# Altium schematic sheet FTDI ISO.SchDoc("FTDI ISO")
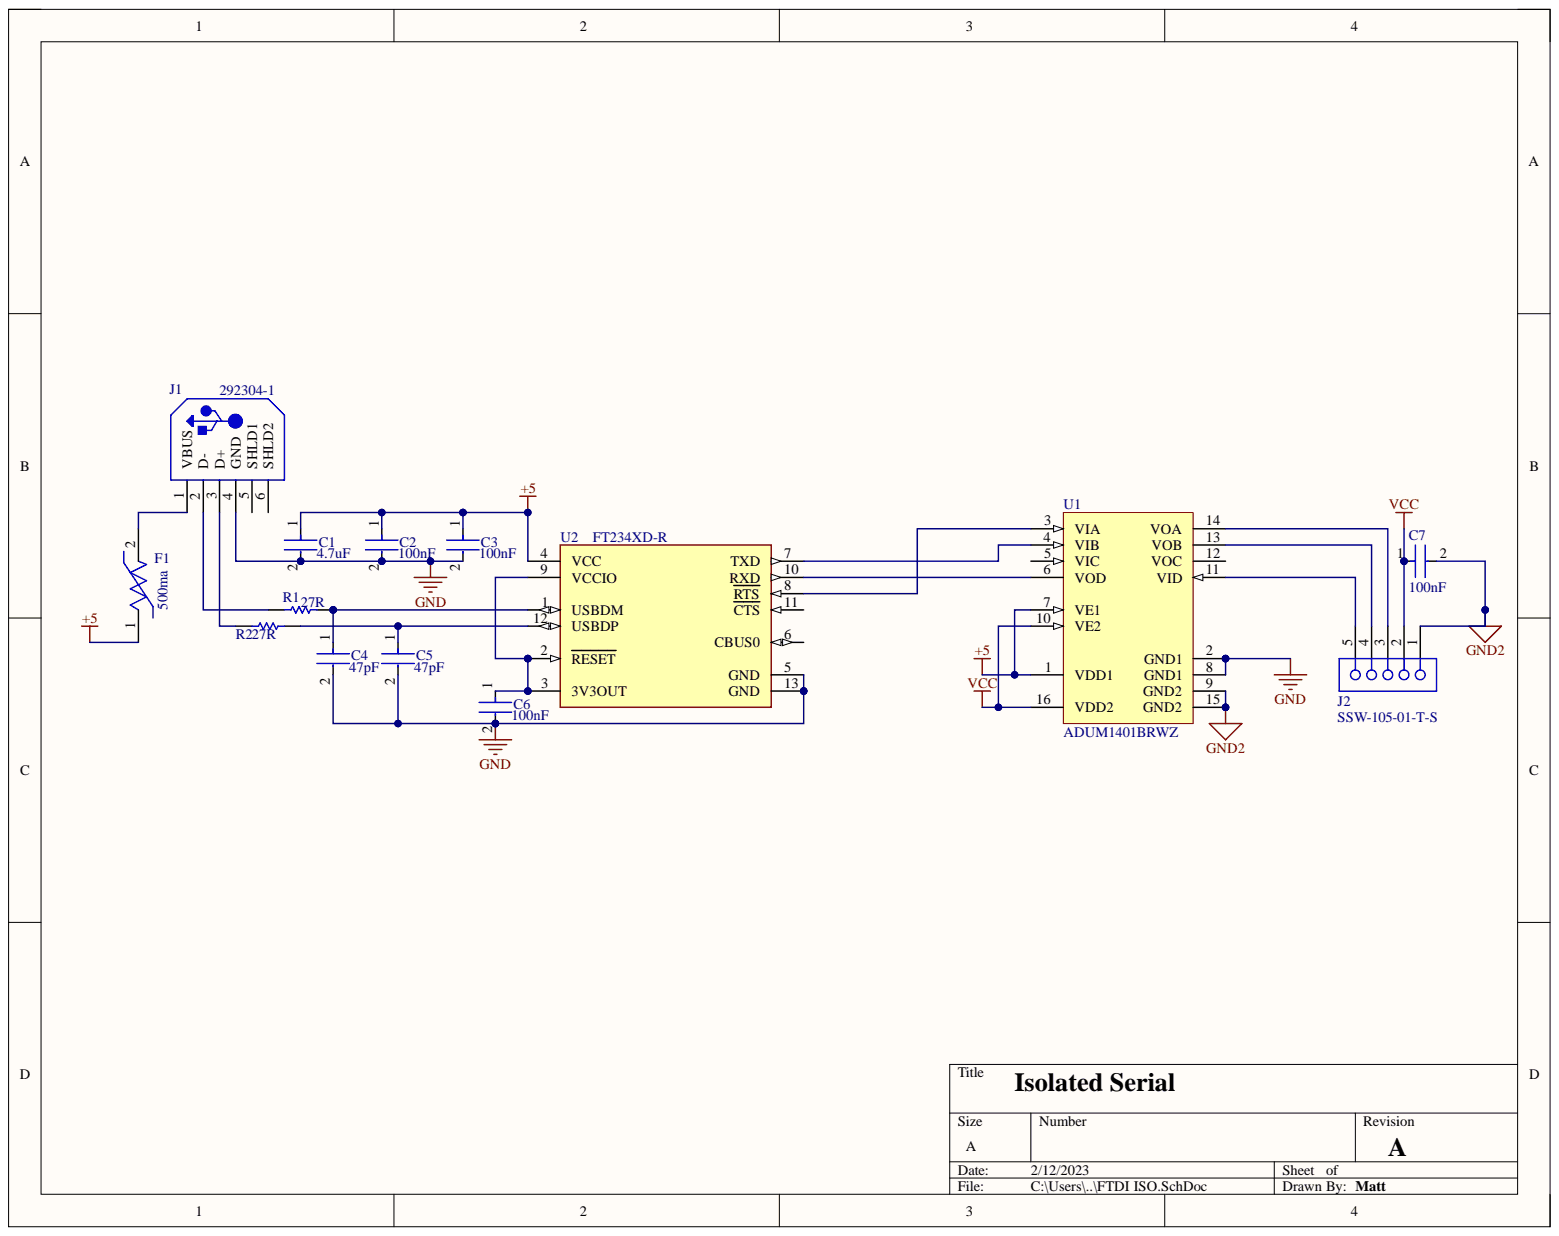
[diagram p0: FTDI ISO.SchDoc]

## Parts
C1  Capacitance: 4.7uF  [FP-CL21-IPC_B]  (hidden comment: CL21A475KAQNNNG)
C2  Capacitance: 100nF  [FP-CL10-IPC_A]  (hidden comment: CL10B104KA8WPNC)
C3  Capacitance: 100nF  [FP-CL10-IPC_A]  (hidden comment: CL10B104KA8WPNC)
C4  Capacitance: 47pF  [FP-0603-L_1_6_0_15-W_0_81-MFG]  (hidden comment: 06035A470JAT2A)
C5  Capacitance: 47pF  [FP-0603-L_1_6_0_15-W_0_81-MFG]  (hidden comment: 06035A470JAT2A)
C6  Capacitance: 100nF  [FP-CL10-IPC_A]  (hidden comment: CL10B104KA8WPNC)
C7  Capacitance: 100nF  [FP-CL10-IPC_A]  (hidden comment: CL10B104KA8WPNC)
F1  500ma  [FP-1206L050YR-MFG]
J1  292304-1  [TECO-292304-1-4_V]
J2  SSW-105-01-T-S  [SMTC-SSW-105-01-X-S]
R1  Parameter 1: 27R  [RESC1005X40X25NL05T10]  (hidden comment: RC0402JR-0727RL)
R2  Parameter 1: 27R  [RESC1005X40X25NL05T10]  (hidden comment: RC0402JR-0727RL)
U1  ADUM1401BRWZ  [ADI-RW-16_M]
U2  FT234XD-R  [FP-DFN-12-IPC_B]

## Nets
+5: C1.1 C2.1 C3.1 F1.1 U1.1(VDD1) U1.7(VE1) U2.4(VCC)
GND: C1.2 C2.2 C3.2 C4.2 C5.2 C6.2 J1.4(GND) U1.2(GND1) U1.8(GND1) U2.5(GND) U2.13(GND)
GND2: C7.2 J2.1 U1.9(GND2) U1.15(GND2)
VCC: C7.1 J2.2 U1.10(VE2) U1.16(VDD2)
NetC4_1: C4.1 R1.2 U2.1(USBDM)
NetC5_1: C5.1 R2.2 U2.12(USBDP)
NetC6_1: C6.1 U2.2(RESET) U2.3(3V3OUT) U2.9(VCCIO)
NetF1_2: F1.2 J1.1(VBUS)
NetJ1_2: J1.2(D-) R1.1
NetJ1_3: J1.3(D+) R2.1
NetJ2_3: J2.3 U1.14(VOA)
NetJ2_4: J2.4 U1.13(VOB)
NetJ2_5: J2.5 U1.11(VID)
NetU1_3: U1.3(VIA) U2.8(RTS)
NetU1_4: U1.4(VIB) U2.7(TXD)
NetU1_6: U1.6(VOD) U2.10(RXD)

Not connected: J1 5(SHLD1) 6(SHLD2); U1 5(VIC) 12(VOC); U2 6(CBUS0) 11(CTS)

## Library data of the parts
C1 = CMP-13271-004010-1: CL21 Series 0805 4.7uF 25V ±10% Tolerance X5R Multilayer Ceramic Chip Capacitor; Case Code (Imperial): 0805; Case Code (Metric): 2012; Case/Package: 0805; Component Kind: Standard; Depth: 1.25mm; Dielectric: X5R; Height: 1.25mm; Lead Free: Lead Free; Length: 2mm; Library Name: Altium Content Vault; Material: Ceramic; Max Operating Temperature: 85°C; Min Operating Temperature: -55°C; Mount: Surface Mount; Package Quantity: 3000; Packaging: Tape and Reel; Radiation Hardening: No; Thickness: 0.055inch; Tolerance: 10%; Voltage: 25V; Voltage Rating: 25V; Width: 0.049inch
C2 C3 C6 C7 = CMP-13271-003556-1: Cap Ceramic 100nF 25V X7R ±10% Pad SMD 0603 +125°C T/R; Case Code (Imperial): 0603; Case Code (Metric): 1608; Case/Package: 0603; Component Kind: Standard; Features: Soft Termination; Length: 0.063inch; Library Name: Altium Content Vault; Max Operating Temperature: 125°C; Min Operating Temperature: -55°C; Mount: Surface Mount; Packaging: Tape and Reel; Ratings: AEC-Q200; Thickness: 0.035inch; Tolerance: 10%; Voltage Rating: 25V; Width: 0.031inch
C4 C5 = CMP-2006-04316-2: General Purpose Ceramic Capacitor, 0603, 47pF, 5%, NP0, 30ppm/°C, 50V; Dielectric: C0G; Case Code (Imperial): 0603; Case Code (Metric): 1608; Case/Package: 0603; Component Kind: Standard; Contact Plating: Tin; Depth: 0.81mm; Height: 0.9mm; Lead Free: Lead Free; Length: 1.6mm; Library Name: Altium Content Vault; Max Operating Temperature: 125°C; Min Operating Temperature: -55°C; Mount: Surface Mount; Number of Pins: 2; Package Quantity: 1; Packaging: Tape and Reel; Radiation Hardening: No; REACH SVHC: No SVHC; RoHS Compliant: Yes; Temperature Coefficient: 30ppm/°C; Termination: SMD/SMT; Thickness: 0.035inch; Tolerance: 5%; Voltage: 50V; Voltage Rating: 50V; Voltage Rating (DC): 50V; Width: 0.032inch
F1 = CMP-08606-000073-1: PTC RESET FUSE 6V 500MA 1206; Approvals: cULus; Current Rating: 100A; Max Power Dissipation: 600mW; Resistance: 700mR; Time to Trip: 0.1s; Trip Current: 1A; Case Code (Imperial): 1206; Case Code (Metric): 3216; Case/Package: 1206; Component Kind: Standard; Height: 0.75mm; Hold Current: 500mA; Lead Free: Lead Free; Length: 3.4mm; Library Name: Altium Content Vault; Max Current Rating: 100A; Max Operating Temperature: 85°C; Max Voltage Rating (DC): 6V; Min Operating Temperature: -40°C; Operating Supply Voltage: 6V; Package Quantity: 4000; Packaging: Tape and Reel; Power Dissipation: 600mW; REACH SVHC: No SVHC; RoHS Compliant: Yes; Series: 1206L; Terminal Material: Copper; Termination: SMD/SMT; Voltage Rating: 6V; Voltage Rating (DC): 6V; Width: 1.8mm; Working Voltage: 6V
J1 = CMP-2000-05412-1: 1 Port Right Angle Through Hole Mount Female Type B USB Connector, 4 Position, -55 to 85 degC, RoHS, Tray; Manufacturer: TE Connectivity; Gender: Receptacle; No. of Positions: 4; SVHC: ToBeAdvised; USB Connector Type: USBTypeB; USB Standard: USB2.0; Component Kind: Standard; Connector Mounting: ThroughHole; Contact Material: CopperAlloy; Contact Plating: Gold; Datasheet URL: https://datasheet.ciiva.com/851/eng-cd-292304-d2-851088.pdf; Datasheet Version: Oct-93; Library Name: Altium Content Vault; Manufacturer URL: http://www.te.com/; Orientation: RightAngle; Series: B
J2 = CMP-1023-00345-1: SQ Post Socket, Through-hole, Vertical, -55 to 105 degC, 2.54mm Pitch, 5-Pin, Female, RoHS; Manufacturer: Samtec; Component Kind: Standard; ComponentLink1Description: Manufacturer URL; ComponentLink1URL: http://www.samtec.com; ComponentLink2Description: Datasheet; ComponentLink2URL: http://www.samtec.com/documents/webfiles/pdf/SSW_TH.PDF; ComponentLink3Description: Package Specification; ComponentLink3URL: http://www.samtec.com/documents/webfiles/cpdf/SSW-1XX-XX-XXX-X-XX-XXX-XX-MKT.pdf; DatasheetVersion: F-214; Library Name: Altium Content Vault; Mounting Technology: Through-hole; Orientation: Vertical; PackageDescription: Assembly, Socket Strip .016 X .031 Tail; PackageReference: SSW-105-01-X-S; PackageVersion: CA, 6/1987; PartNumber: SSW-105-01-T-S; Pitch: 2.54 mm; RoHS: True; Temperature Range: -55 to 105 degC
R1 R2 = CMP-1011-00036-2: Manufacturer: Yageo / Phycomp; Component Kind: Standard; Library Name: Altium Content Vault; Part Number: RC0402JR-0727RL
U1 = CMP-0534-00565-1: Quad Channel Digital Isolator, 2.5 KV rms Insulation Rating, 10 Mbps Data Rate, 50 ns Delay, Industrial, 16-pin SOIC (RW-16), Tube; Manufacturer: Analog Devices; Max Data Rate: 10Mbps; Code_JEDEC: MS-013-AA; Component Kind: Standard; ComponentLink1Description: Manufacturer URL; ComponentLink1URL: http://www.analog.com/en/index.html; ComponentLink2Description: Datasheet; ComponentLink2URL: http://www.analog.com/static/imported-files/data_sheets/ADuM1400_1401_1402.pdf; ComponentLink3Description: Package Specification; ComponentLink3URL: http://www.analog.com/static/imported-files/packages/PKG_PDF/SOIC_WIDE%20%28RW%29/RW_16.pdf; ComponentLink4Description: URL Link1; ComponentLink4URL: Product URL:http://www.analog.com/en/interface-isolation/digital-isolators/adum1401/products/product.html; ComponentLink5Description: URL Link2; ComponentLink5URL: Reference Circuit:http://www.analog.com/en/interface-isolation/digital-isolators/adum1401/products/product.html#product-recommendations; DatasheetVersion: Rev. H; Library Name: Altium Content Vault; Max Operating Temp (degreesC): 125; Mounting Technology: Surface Mount; PackageDescription: 16-Pin Standard Small Outline Package, Body 10.3 x 7.5 mm, Pitch 1.27 mm; PackageReference: RW-16; PackageVersion: 032707-B, 03/2007; PartNumber: ADUM1401BRWZ
U2 = CMP-0248-00027-3: IC USB SERIAL BASIC UART 12DFN; Data Rate: 3Mbps; Max Supply Voltage: 5.5V; Min Supply Voltage: 2.97V; Number of Ports: 1; Operating Supply Current: 8mA; Operating Supply Voltage: 5V; Case/Package: DFN; Component Kind: Standard; Height: 0.75mm; Interface: UART; Lead Free: Lead Free; Length: 3mm; Library Name: Altium Content Vault; Max Operating Temperature: 85°C; Min Operating Temperature: -40°C; Mount: Surface Mount; Number of Pins: 12; Number of Transceivers: 1; Package Quantity: 5000; Packaging: Tape and Reel; REACH SVHC: No SVHC; Width: 3mm
